# Altium schematic sheet Regulator.SchDoc(Regulator)
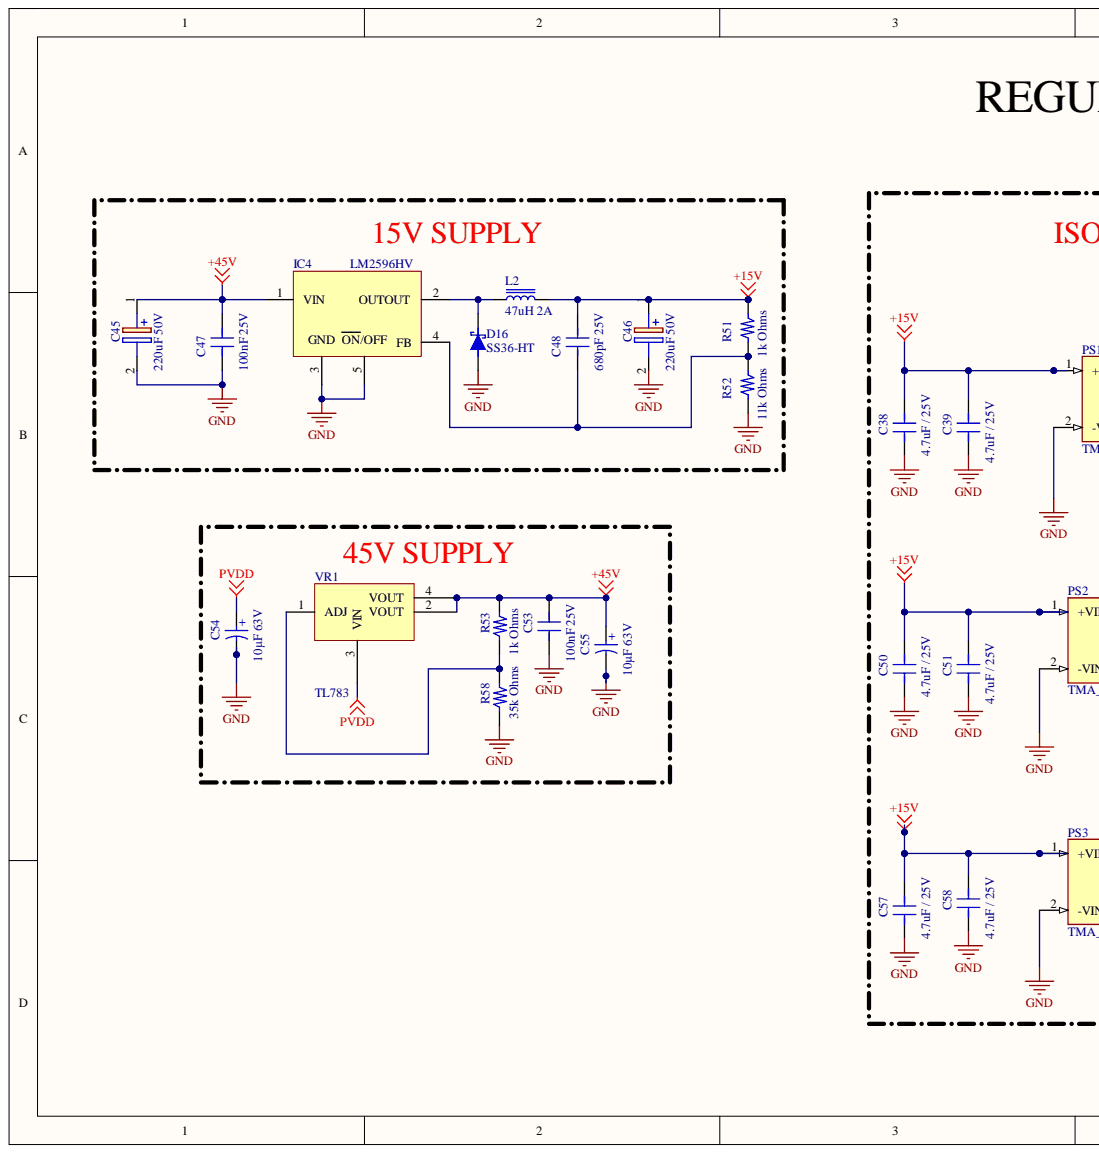
[diagram p0: Regulator.SchDoc, left]
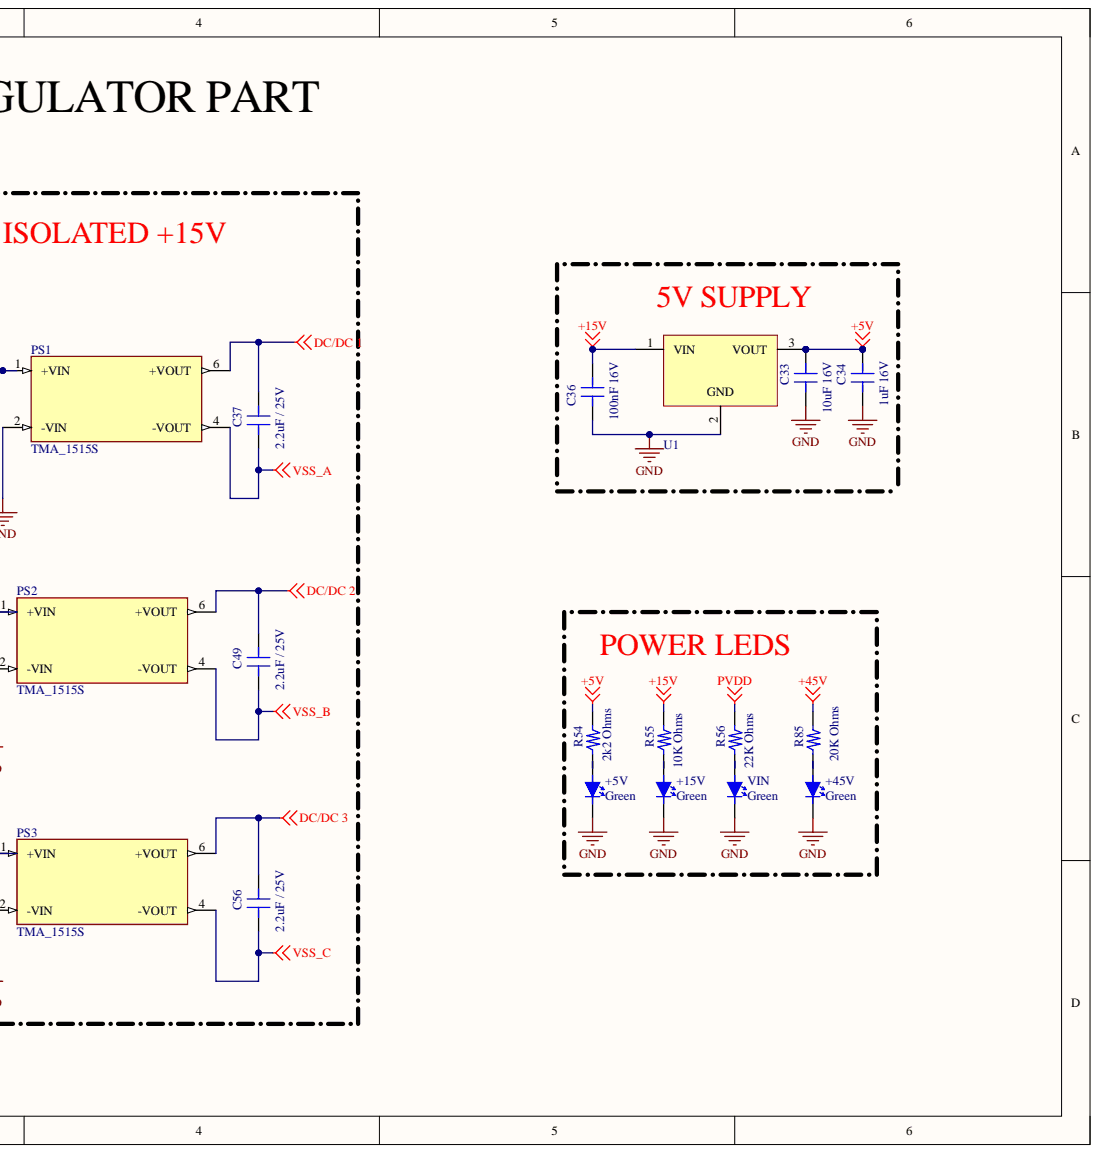
[diagram p1: Regulator.SchDoc, right]

## Parts
+5V  Green  [0805LED]
+15V  Green  [0805LED]
+45V  Green  [0805LED]
C33  10uF 16V  [0805_CAP]
C34  1uF 16V  [0805_CAP]
C36  100nF 16V  [0805_CAP]
C37  2.2uF / 25V  [0805_CAP]
C38  4.7uF / 25V  [0805_CAP]
C39  4.7uF / 25V  [0805_CAP]
C45  220uF 50V  [CAPAE1030X1060N]
C46  220uF 50V  [CAPAE1030X1060N]
C47  100nF 25V  [0805_CAP]
C48  680pF 25V  [0805_CAP]
C49  2.2uF / 25V  [0805_CAP]
C50  4.7uF / 25V  [0805_CAP]
C51  4.7uF / 25V  [0805_CAP]
C53  100nF 25V  [0805_CAP]
C54  10µF 63V  Rated Voltage (DC): 63V  [WCAP-ATG5_D5H11]
C55  10µF 63V  Rated Voltage (DC): 63V  [WCAP-ATG5_D5H11]
C56  2.2uF / 25V  [0805_CAP]
C57  4.7uF / 25V  [0805_CAP]
C58  4.7uF / 25V  [0805_CAP]
D16  SS36-HT  [DO-214AB]
IC4  LM2596HV  [TO-263-5]
L2  47uH 2A  [BOURNS SRR1280]
PS1  TMA_1515S  [CONV_TMA_1515S]
PS2  TMA_1515S  [CONV_TMA_1515S]
PS3  TMA_1515S  [CONV_TMA_1515S]
R51  1k Ohms  [0805_RES]
R52  11k Ohms  value 1k Ohms  [0805_RES]
R53  1k Ohms  [0805_RES]
R54  2k2 Ohms  [0805_RES]
R55  10K Ohms  [0805_RES]
R56  22K Ohms  [0805_RES]
R58  35k Ohms  [0805_RES]
R85  20K Ohms  [0805_RES]
U1  Output Voltage: 5V  [WPME-FDSM_SIP-3_L11.6W8.5H10.5]  (hidden comment: 8-28Vin, 5Vout, 1Aout)
VIN  Green  [0805LED]
VR1  TL783  [TL783]

## Nets
+5V: C33.2 C34.2 R54.1 U1.3
+15V: C36.2 C38.2 C39.2 C46.1 C48.2 C50.2 C51.2 C57.2 C58.2 L2.2 PS1.1(+VIN) PS2.1(+VIN) PS3.1(+VIN) R51.2 R55.1 U1.1
+45V: C45.1 C47.2 C53.2 C55.1 IC4.1(VIN) R53.1 R85.1 VR1.2(VOUT) VR1.4(VOUT)
DC/DC 1: C37.2 PS1.6(+VOUT)
DC/DC 2: C49.2 PS2.6(+VOUT)
DC/DC 3: C56.2 PS3.6(+VOUT)
GND: +5V.2 +15V.2 +45V.2 C33.1 C34.1 C36.1 C38.1 C39.1 C45.2 C46.2 C47.1 C50.1 C51.1 C53.1 C54.2 C55.2 C57.1 C58.1 D16.1 IC4.3 IC4.5 PS1.2(-VIN) PS2.2(-VIN) PS3.2(-VIN) R52.1 R58.2 U1.2 VIN.2
PVDD: C54.1 R56.1 VR1.3(VIN)
VSS_A: C37.1 PS1.4(-VOUT)
VSS_B: C49.1 PS2.4(-VOUT)
VSS_C: C56.1 PS3.4(-VOUT)
Net+5V_1: +5V.1 R54.2
Net+15V_1: +15V.1 R55.2
Net+45V_1: +45V.1 R85.2
NetC48_1: C48.1 IC4.4(FB) R51.1 R52.2
NetD16_2: D16.2 IC4.2(OUTOUT) L2.1
NetR53_2: R53.2 R58.1 VR1.1(ADJ)
NetR56_2: R56.2 VIN.1

## Library data of the parts
+5V +15V +45V VIN = LED: 0805; Component Kind: Standard; Library Name: HidroanaSCHLib.SchLib
C33 C34 C36 C47 C48 C53 = CAP_0805: Component Kind: Standard; Library Name: HidroanaSCHLib.SchLib
  C33: Value: 10uF 16V
  C34: Value: 1uF 16V
  C36: Value: 100nF 16V
  C47 C53: Value: 100nF 25V
  C48: Value: 680pF 25V
C37-C39 C49-C51 C56-C58 = CAP_0805: Value: 100nF 25V; Component Kind: Standard; Library Name: HidroanaSCHLib.SchLib
C45 C46 = CK1H221M-CRG10: Capacitor Polarised; Manufacturer_Name: SEMTECH; Component Kind: Standard; Datasheet Link: https://datasheet.lcsc.com/szlcsc/1810281207_ST-Semtech-CK1H221M-CRG10_C131159.pdf; Height: 10.6mm; Manufacturer_Part_Number: CK1H221M-CRG10; Library Name: HidroanaSCHLib.SchLib
C54 C55 = 860020772010: WCAP-ATG5 Aluminum Electrolytic Capacitor, Radial, THT, D5 x H11mm, 10µF, 63V; Manufacturer: Wurth Elektronik; Capacitance Tolerance: +/-20%; Dissipation Factor: 9%; Endurance: 2000h; Leakage Current: 6.3µA; Ripple Current: 42mA; Technical Reference: ATDC110100M063DSPA3B000; Capacitance: 10µF 63V; Category: Aluminum Electrolytic Capacitors; Component Kind: Standard; ComponentLink1Description: Website Link; ComponentLink1URL: https://www.we-online.com/redexpert/article/860020772010?ad; ComponentLink2Description: Datasheet Link; ComponentLink2URL: https://www.we-online.com/redexpert/spec/860020772010?ad; Diameter: 5mm; Height: 11mm; Manufacturer Part Number: 860020772010; Match Code: WCAP-ATG5; Mount: Through Hole; Operating Temperature Max: 105°C; Operating Temperature Min: -40°C; Packaging: Ammo Pack; Simulation: 860020772010_10uF; Library Name: Hidroana Lib.IntLib
D16 = SS36-214AB-HT: Schottky Diode; Component Kind: Standard; ComponentLink1Description: Özdisan; ComponentLink1URL: https://ozdisan.com/guc-yari-iletkenleri/diyotlar-modul-diyotlar-ve-dogrultucular/schottky-diyotlar/SS36-214AB-HT/475999; ComponentLink2Description: Datasheet; ComponentLink2URL: https://cdn.ozdisan.com/ETicaret_Dosya/475999_7494647.pdf; PartNumber: SS36-HT; Library Name: HidroanaSCHLib.SchLib
IC4 = LM2596HV: Integrated Circuit; Manufacturer_Name: Texas Instruments; Arrow Part Number: LM2596S-12; Arrow Price/Stock: https://www.arrow.com/en/products/lm2596s-12/texas-instruments; Component Kind: Standard; Datasheet Link: http://www.ti.com/lit/ds/symlink/lm2596.pdf; Mouser Part Number: 926-LM2596S-12; Mouser Price/Stock: https://www.mouser.co.uk/ProductDetail/Texas-Instruments/LM2596S-12?qs=X1J7HmVL2ZEK1FPBBSYJCA%3D%3D; PartValue: LM2596HV; Library Name: HidroanaSCHLib.SchLib
L2 = Inductor: FIXED IND 47UH 2.9A 80 MOHM SMD; Component Kind: Standard; Value: 47uH 2A; Library Name: HidroanaSCHLib.SchLib
PS1-PS3 = TMA_1515S: MF: {'manufacturer': u'Traco Power'}; Availability: Unavailable; Component Kind: Standard; Library Name: TMA_1515S.IntLib; MP: TMA_1515S; Package: None; Price: None
R51 = RES_0805: 0805; Power: 1/8 W; Value: 11k Ohms; Component Kind: Standard; Library Name: HidroanaSCHLib.SchLib
R52-R56 R58 R85 = RES_0805: 0805; Power: 1/8 W; Component Kind: Standard
  R53: Value: 1k Ohms
  R54: Value: 2k2 Ohms
  R55: Value: 10K Ohms
  R56: Value: 22K Ohms
  R58: Value: 35k Ohms
  R85: Value: 20K Ohms
  R52 R54-R56 R85: Library Name: HidroanaSCHLib.SchLib
  R53 R58: Library Name: Hidroana Lib.IntLib
U1 = 173010578: WPME-FDSM Fixed Step Down Regulator Module, THT, SIP-3, 8-28Vin, 5Vout, 1Aout; Manufacturer: Wurth Elektronik; Input Voltage Max: 28V; Input Voltage Min: 8V; Output Current: 1A; Case/Size Code: SIP-3; Category: Step Down Converter; Component Kind: Standard; ComponentLink1Description: Website Link; ComponentLink1URL: https://www.we-online.com/redexpert/article/173010578?ad; ComponentLink2Description: Datasheet Link; ComponentLink2URL: https://www.we-online.com/redexpert/spec/173010578?ad; Height: 10.5mm; Length: 11.6mm; Library Name: MagIC-FDSM (rev22e).IntLib; Manufacturer Part Number: 173010578; Match Code: WPME-FDSM; Mount: Through Hole; Operating Temperature Max: 85°C; Operating Temperature Min: -40°C; Packaging: Tube; Width: 8.5mm
VR1 = TL783/SMD: Component Kind: Standard; Library Name: HidroanaSCHLib1.SchLib
